# Altium schematic sheet CONNECTEUR_1.SchDoc
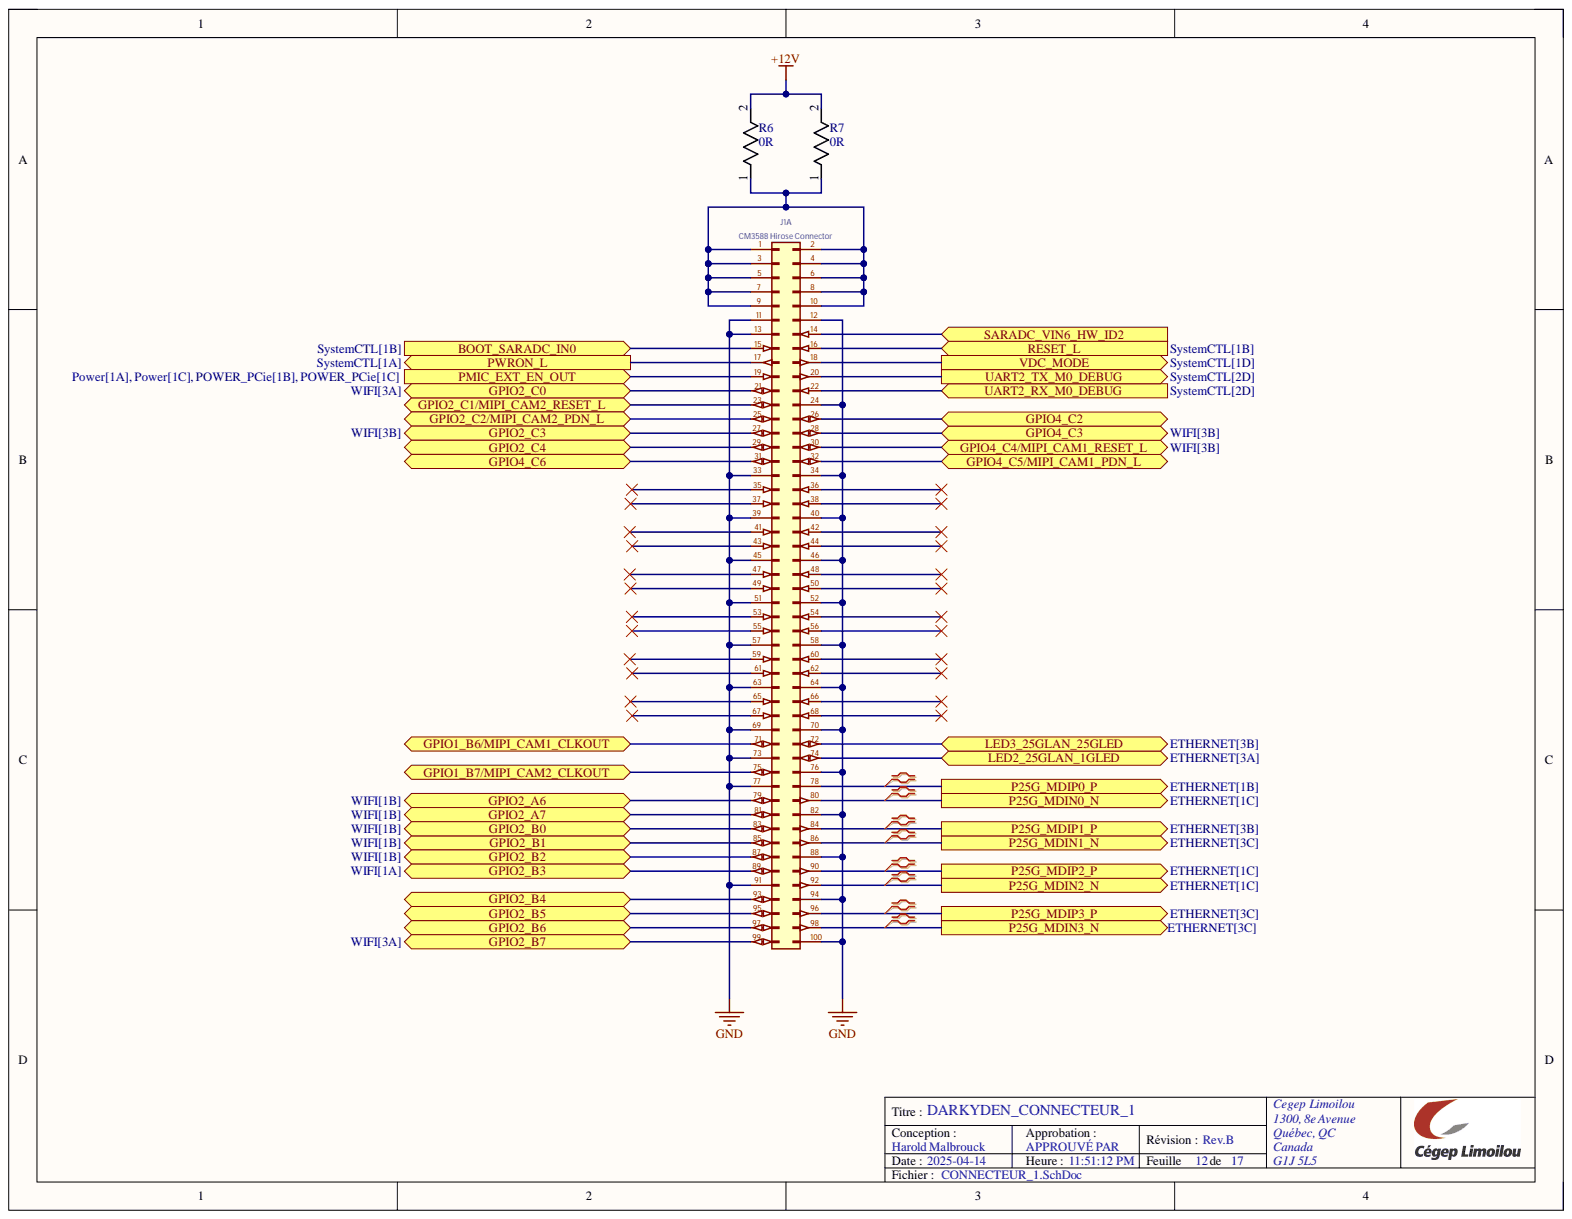
[diagram p0: CONNECTEUR_1.SchDoc]

## Parts
J1  CM3588 Hirose Connector  [Library:CM3588]  (part A)
R6  0R  [RES_0816_SUS]
R7  0R  [RES_0816_SUS]

## Ports
BOOT_SARADC_IN0, GPIO1_B6/MIPI_CAM1_CLKOUT, GPIO1_B7/MIPI_CAM2_CLKOUT, GPIO2_A6, GPIO2_A7, GPIO2_B0, GPIO2_B1, GPIO2_B2, GPIO2_B3, GPIO2_B4, GPIO2_B5, GPIO2_B6, GPIO2_B7, GPIO2_C0, GPIO2_C1/MIPI_CAM2_RESET_L, GPIO2_C2/MIPI_CAM2_PDN_L, GPIO2_C3, GPIO2_C4, GPIO4_C2, GPIO4_C3, GPIO4_C4/MIPI_CAM1_RESET_L, GPIO4_C5/MIPI_CAM1_PDN_L, GPIO4_C6, LED2_25GLAN_1GLED, LED3_25GLAN_25GLED, P25G_MDIN0_N, P25G_MDIN1_N, P25G_MDIN2_N, P25G_MDIN3_N, P25G_MDIP0_P, P25G_MDIP1_P, P25G_MDIP2_P, P25G_MDIP3_P, PMIC_EXT_EN_OUT, PWRON_L, RESET_L, SARADC_VIN6_HW_ID2, UART2_RX_M0_DEBUG, UART2_TX_M0_DEBUG, VDC_MODE

## Nets
+12V: R6.2 R7.2
GND: J1.11 J1.12 J1.13 J1.24 J1.33 J1.34 J1.39 J1.40 J1.45 J1.46 J1.51 J1.52 J1.57 J1.58 J1.63 J1.64 J1.69 J1.70 J1.73 J1.76 J1.77 J1.82 J1.88 J1.91 J1.94 J1.100
BOOT_SARADC_IN0: J1.15 ; port BOOT_SARADC_IN0
GPIO1_B6/MIPI_CAM1_CLKOUT: J1.71 ; port GPIO1_B6/MIPI_CAM1_CLKOUT
GPIO1_B7/MIPI_CAM2_CLKOUT: J1.75 ; port GPIO1_B7/MIPI_CAM2_CLKOUT
GPIO2_A6: J1.79 ; port GPIO2_A6
GPIO2_A7: J1.81 ; port GPIO2_A7
GPIO2_B0: J1.83 ; port GPIO2_B0
GPIO2_B1: J1.85 ; port GPIO2_B1
GPIO2_B2: J1.87 ; port GPIO2_B2
GPIO2_B3: J1.89 ; port GPIO2_B3
GPIO2_B4: J1.93 ; port GPIO2_B4
GPIO2_B5: J1.95 ; port GPIO2_B5
GPIO2_B6: J1.97 ; port GPIO2_B6
GPIO2_B7: J1.99 ; port GPIO2_B7
GPIO2_C0: J1.21 ; port GPIO2_C0
GPIO2_C1/MIPI_CAM2_RESET_L: J1.23 ; port GPIO2_C1/MIPI_CAM2_RESET_L
GPIO2_C2/MIPI_CAM2_PDN_L: J1.25 ; port GPIO2_C2/MIPI_CAM2_PDN_L
GPIO2_C3: J1.27 ; port GPIO2_C3
GPIO2_C4: J1.29 ; port GPIO2_C4
GPIO4_C2: J1.26 ; port GPIO4_C2
GPIO4_C3: J1.28 ; port GPIO4_C3
GPIO4_C4/MIPI_CAM1_RESET_L: J1.30 ; port GPIO4_C4/MIPI_CAM1_RESET_L
GPIO4_C5/MIPI_CAM1_PDN_L: J1.32 ; port GPIO4_C5/MIPI_CAM1_PDN_L
GPIO4_C6: J1.31 ; port GPIO4_C6
LED2_25GLAN_1GLED: J1.74 ; port LED2_25GLAN_1GLED
LED3_25GLAN_25GLED: J1.72 ; port LED3_25GLAN_25GLED
P25G_MDIN0_N: J1.80 ; port P25G_MDIN0_N
P25G_MDIN1_N: J1.86 ; port P25G_MDIN1_N
P25G_MDIN2_N: J1.92 ; port P25G_MDIN2_N
P25G_MDIN3_N: J1.98 ; port P25G_MDIN3_N
P25G_MDIP0_P: J1.78 ; port P25G_MDIP0_P
P25G_MDIP1_P: J1.84 ; port P25G_MDIP1_P
P25G_MDIP2_P: J1.90 ; port P25G_MDIP2_P
P25G_MDIP3_P: J1.96 ; port P25G_MDIP3_P
PMIC_EXT_EN_OUT: J1.19 ; port PMIC_EXT_EN_OUT
PWRON_L: J1.17 ; port PWRON_L
RESET_L: J1.16 ; port RESET_L
SARADC_VIN6_HW_ID2: J1.14 ; port SARADC_VIN6_HW_ID2
UART2_RX_M0_DEBUG: J1.22 ; port UART2_RX_M0_DEBUG
UART2_TX_M0_DEBUG: J1.20 ; port UART2_TX_M0_DEBUG
VDC_MODE: J1.18 ; port VDC_MODE
NetJ1_1: J1.1 J1.2 J1.3 J1.4 J1.5 J1.6 J1.7 J1.8 J1.9 J1.10 R6.1 R7.1

Not connected: J1 35 36 37 38 41 42 43 44 47 48 49 50 53 54 55 56 59 60 61 62 65 66 67 68

## Library data of the parts
J1 = CM3588: Component Kind: Standard; LCSC: C597931; Library Name: cm3588.SchLib; Value: CM3588 Hirose Connector
R6 R7 = RG1608P-104-B-T5: No Description Available; Mfr_Name: Susumu; Component Kind: Standard; Library Name: RES_SMD_0603.SchLib
